# Altium schematic sheet Skywire BBBC.SchDoc
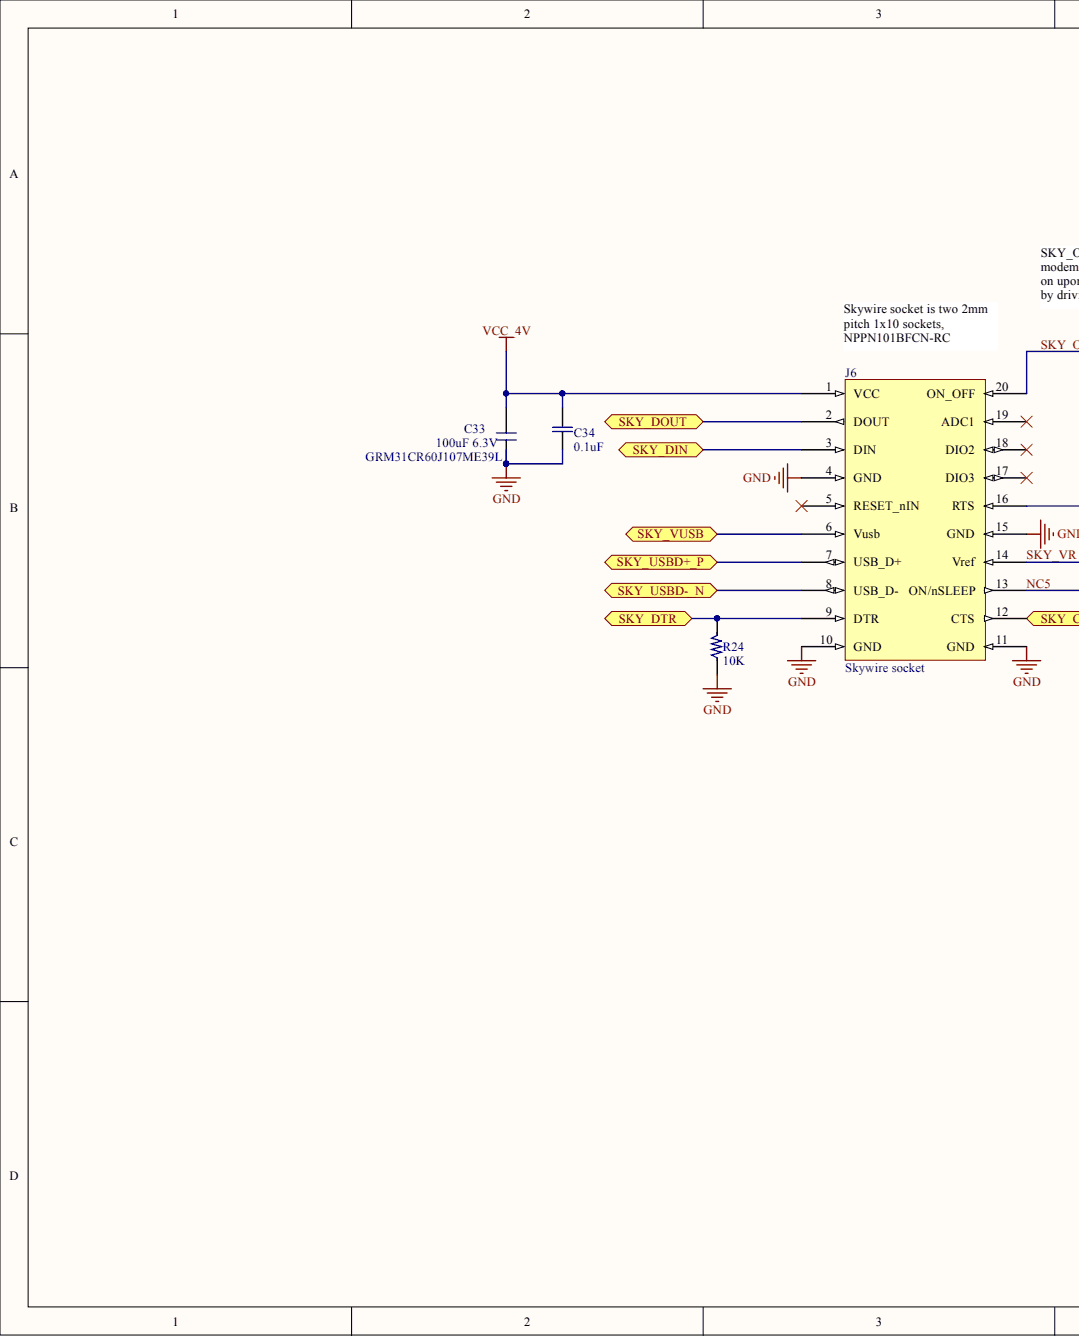
[diagram p0: Skywire BBBC.SchDoc, left]
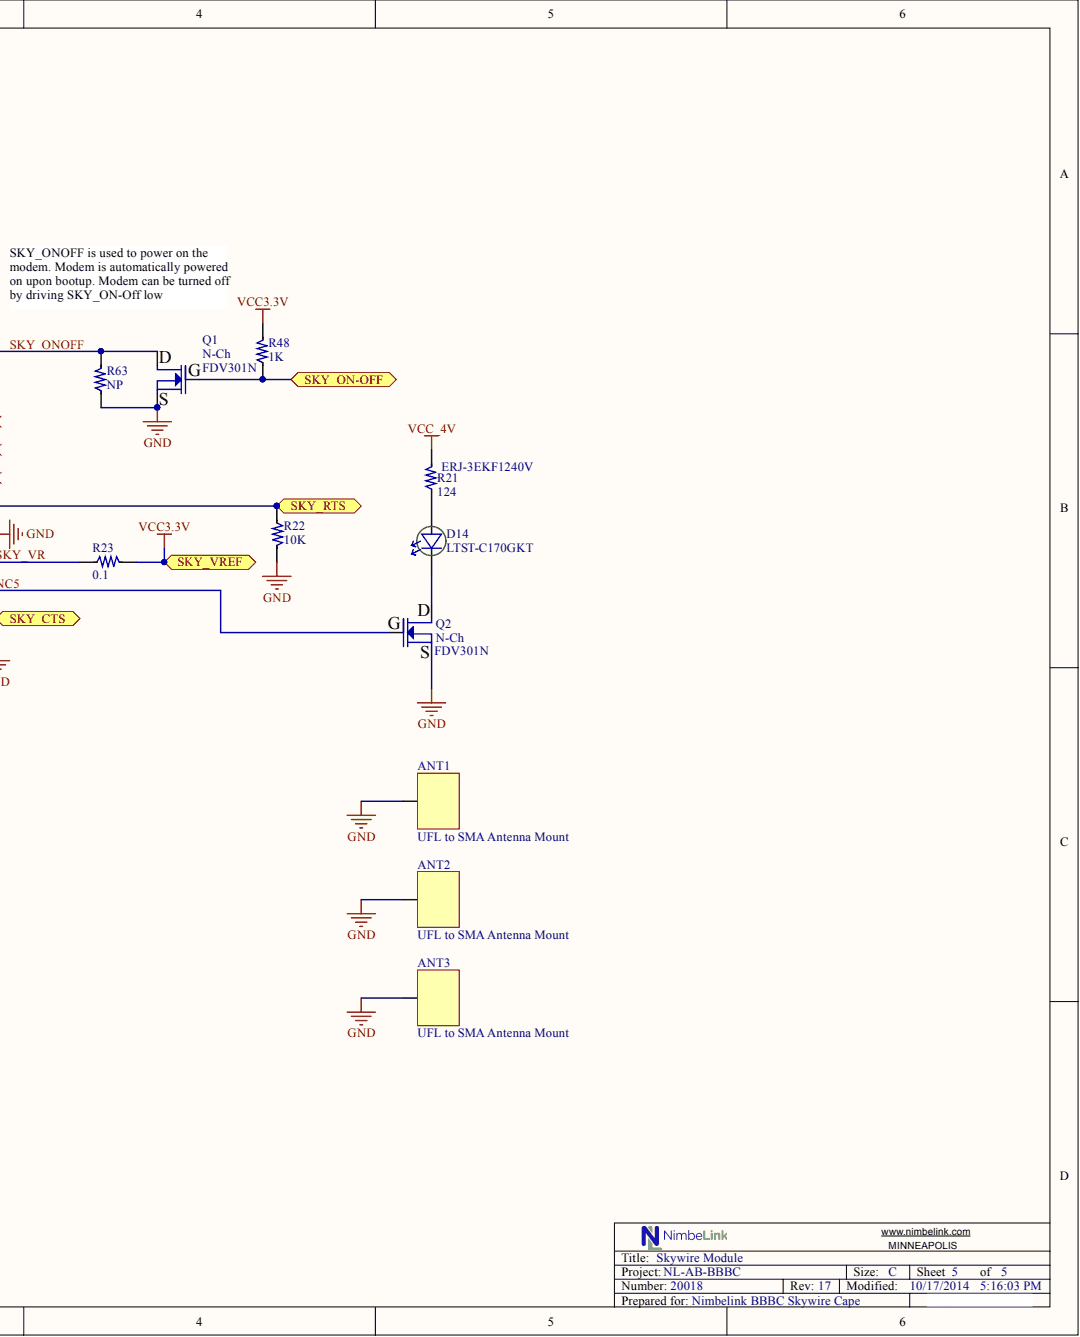
[diagram p1: Skywire BBBC.SchDoc, right]

## Parts
ANT1  UFL to SMA Antenna Mount  [UFL TO SMA]
ANT2  UFL to SMA Antenna Mount  [UFL TO SMA]
ANT3  UFL to SMA Antenna Mount  [UFL TO SMA]
C33  100uF 6.3V  Manufacturer Part Number 1: GRM31CR60J107ME39L  Voltage - Rated: 6.3V  [1206]
C34  0.1uF  [0603]
D14  Manufacturer Part Number 1: LTST-C170GKT  [D_LED_GREEN]  (hidden comment: D_LED_GREEN)
J6  Skywire socket  [SKYWIRE SOCKET]
Q1  N-Ch  Manufacturer Part Number 2: FDV301N  [SOT-23-3]
Q2  N-Ch  Manufacturer Part Number 2: FDV301N  [SOT-23-3]
R21  124  Manufacturer Part Number 1: ERJ-3EKF1240V  [0603]
R22  10K  [0603]
R23  0.1  [0805]
R24  10K  [0603]
R48  1K  [0603]
R63  NP  [0603]

## Ports
SKY_CTS, SKY_DIN, SKY_DOUT, SKY_DTR, SKY_ON-OFF, SKY_RTS, SKY_USBD+_P, SKY_USBD-_N, SKY_VREF, SKY_VUSB

## Nets
GND: ANT1.1 ANT2.1 ANT3.1 C33.2 C34.2 J6.4(GND) J6.10(GND) J6.11(GND) J6.15(GND) Q1.3 Q2.3 R22.2 R24.1 R63.1
VCC_4V: C33.1 C34.1 J6.1(VCC) R21.2
NC5: J6.13(ON/nSLEEP) Q2.1
SKY_CTS: J6.12(CTS) ; port SKY_CTS
SKY_DIN: J6.3(DIN) ; port SKY_DIN
SKY_DOUT: J6.2(DOUT) ; port SKY_DOUT
SKY_DTR: J6.9(DTR) R24.2 ; port SKY_DTR
SKY_ON-OFF: Q1.1 R48.1 ; port SKY_ON-OFF
SKY_ONOFF: J6.20(ON_OFF) Q1.2 R63.2
SKY_RTS: J6.16(RTS) R22.1 ; port SKY_RTS
SKY_USBD+_P: J6.7(USB_D+) ; port SKY_USBD+_P
SKY_USBD-_N: J6.8(USB_D-) ; port SKY_USBD-_N
SKY_VR: J6.14(Vref) R23.1
SKY_VUSB: J6.6(Vusb) ; port SKY_VUSB
VCC3.3V: R23.2 R48.2 ; port SKY_VREF
NetD14_1: D14.1 R21.1
NetD14_2: D14.2 Q2.2

Not connected: J6 5(RESET_nIN) 17(DIO3) 18(DIO2) 19(ADC1)

## Library data of the parts
ANT1-ANT3 = MTG UFL to SMA: Antenna Socket; Component Kind: Standard; Library Name: Nimbelink Altium Lib.SchLib
C33 = Cap 100uF 6.3V 20% X5R 1206 CER: Manufacturer 1: Murata Electronics North America; Capacitance: 100µF; Applications: General Purpose; Category: Capacitors; Component Kind: Standard; ComponentLink1Description: http://www.murata.com/products/catalog/pdf/c02e.pdf; ComponentLink1URL: http://www.murata.com/products/catalog/pdf/c02e.pdf; Description: Cap 100uF 6.3V 20% X5R 1206 CER; Features: -; Height - Seated (Max): -; Lead Spacing: -; Lead Style: -; Mounting Type: Surface Mount, MLCC; Operating Temperature: -55°C ~ 85°C; Package / Case: 1206 (3216 Metric); Packaging: Cut Tape (CT); Pricing: 1=0.81, 10=0.689, 50=0.507, 100=0.403, 250=0.338, 500=0.3055, 1000=0.2795 (USD); Ratings: -; RoHS: RoHS Compliant; Size / Dimension: 0.126" L x 0.063" W (3.20mm x 1.60mm); Stock: 5629; Supplier 1: Digi-Key; Supplier Part Number 1: 490-4539-1-ND; Temperature Coefficient: X5R; Thickness (Max): 0.071" (1.80mm); Tolerance: ±20%; Library Name: Nimbelink Altium Lib.SchLib
C34 = Cap 0.1uF 16V 10% X7R 0603 CER: Manufacturer 1: Samsung Electro-Mechanics America, Inc; Manufacturer Part Number 1: CL10B104KO8NNNC; Capacitance: 0.1µF; Applications: General Purpose; Category: Capacitors; Component Kind: Standard; ComponentLink1Description: http://www.samsungsem.com/servlet/FileDownload?type=spec&file=CL10B104KO8NNNC.pdf; ComponentLink1URL: http://www.samsungsem.com/servlet/FileDownload?type=spec&file=CL10B104KO8NNNC.pdf; Description: Cap 0.1uF 16V 10% X7R 0603 CER; Features: -; Height - Seated (Max): -; Lead Spacing: -; Lead Style: -; Mounting Type: Surface Mount, MLCC; Operating Temperature: -55°C ~ 125°C; Package / Case: 0603 (1608 Metric); Packaging: Cut Tape (CT); Pricing: 1=0.1, 10=0.019, 25=0.0132, 50=0.0102, 100=0.0086, 250=0.00704, 500=0.006, 1000=0.00468 (USD); Ratings: -; RoHS: RoHS Compliant; Size / Dimension: 0.063" L x 0.031" W (1.60mm x 0.80mm); Stock: 1252951; Supplier 1: Digi-Key; Supplier Part Number 1: 1276-1005-1-ND; Temperature Coefficient: X7R; Thickness (Max): 0.035" (0.90mm); Tolerance: ±10%; Voltage - Rated: 16V; Library Name: Nimbelink Altium Lib.SchLib
D14 = D_LED_GREEN: LED GREEN  SMD; Brand: Lite-On; Forward Current: 20 mA; Forward Voltage: 2.1 V; LED Size: 2 mm x 1.25 mm; Lens Color/Style: Water Clear; Luminous Intensity: 6 mcd; Viewing Angle: 130 deg; Wavelength/Color Temperature: 569 nm; Category: Standard LEDs - SMD; Component Kind: Standard; ComponentLink1Description: http://www.mouser.com/catalog/specsheets/Lite-On-LTST-C170GKT.pdf; ComponentLink1URL: http://www.mouser.com/catalog/specsheets/Lite-On-LTST-C170GKT.pdf; Illumination Color: Green; Manufacturer 1: Lite-On; Maximum Operating Temperature: + 85 C; Minimum Operating Temperature: - 55 C; Minimum Order Quantity: 1; Package / Case: 0805; Packaging: Reel; Pricing: 1=0.513, 10=0.371, 100=0.217, 250=0.154, 500=0.115, 1000=0.089, 3000=0.077, 6000=0.063, 12000=0.058 (AUD); Product Category: SMT LED; Reeling: True; RoHS: RoHS Compliant; RoHS - Mouser: Y; Standard Pack Qty: 3000; Stock: 13646; Supplier 1: Mouser; Supplier Part Number 1: 859-LTST-C170GKT; Library Name: Nimbelink Altium Lib.SchLib
J6 = Skywire socket: Manufacturer 1: Sullins Connector Solutions; Manufacturer Part Number 1: NPPN101BFCN-RC; Connector Type: Header; Number of Positions: 10; Number of Positions Loaded: All; Pitch: 0.079" (2.00mm); Category: Connectors, Interconnects; Color: Black; Component Kind: Standard; ComponentLink1Description: http://www.sullinscorp.com/drawings/75_1BFC_10483.pdf; ComponentLink1URL: http://www.sullinscorp.com/drawings/75_1BFC_10483.pdf; Contact Finish: Gold; Contact Finish Thickness: Flash; Contact Type: Female Socket; Fastening Type: -; Features: -; Mounting Type: Through Hole; Number of Rows: 1; Packaging: Bulk; Pricing: 1=1.23, 10=1.018, 25=0.9424, 50=0.8482, 100=0.754, 250=0.65976, 500=0.6032, 1000=0.54665, 2500=0.4901 (USD); Quantity: 2; RoHS: RoHS Compliant; Row Spacing: -; Stock: 17316; Supplier 1: Digi-Key; Supplier Part Number 1: S5751-10-ND; Termination: Solder; Library Name: Nimbelink Altium Lib.SchLib
Q1 Q2 = Q MOSFET N-CH 25V 220MA SOT-23: MOSFET N-CH 25V 220MA SOT-23; Brand: Fairchild Semiconductor; Channel Mode: Enhancement; Configuration: Single; Fall Time: 6 ns; Forward Transconductance - Min: 0.2 S; Pd - Power Dissipation: 0.35 W; Rds On - Drain-Source Resistance: 5 Ohms; Transistor Polarity: N-Channel; Typical Turn-Off Delay Time: 3.5 ns; Vgs - Gate-Source Breakdown Voltage: 8 V; Category: MOSFET; Component Kind: Standard; ComponentLink1Description: http://www.mouser.com/ds/2/149/FDV301N-52768.pdf; ComponentLink1URL: http://www.mouser.com/ds/2/149/FDV301N-52768.pdf; Id - Continuous Drain Current: 220 mA; Manufacturer 2: Fairchild Semiconductor; Maximum Operating Temperature: + 150 C; Minimum Operating Temperature: - 55 C; Minimum Order Quantity: 1; Mounting Style: SMD/SMT; Package / Case: SOT-23-3; Packaging: Reel; Pricing: 1=0.311, 10=0.209, 100=0.086, 1000=0.059, 3000=0.045, 9000=0.038, 24000=0.035, 45000=0.034, 99000=0.028 (AUD); Reeling: True; Rise Time: 6 ns; RoHS: RoHS Compliant; RoHS - Mouser: Y; Series: FDV301N; Standard Pack Qty: 3000; Stock: 403063; Supplier 1: Farnell; Supplier 2: Mouser; Supplier Part Number 1: 9845011; Supplier Part Number 2: 512-FDV301N; Vds - Drain-Source Breakdown Voltage: 25 V; Library Name: Nimbelink Altium Lib.SchLib
R21 = RES 124 1/10W 1% 0603: Brand: Panasonic; Case Height: 0.45 mm; Case Length: 1.6 mm; Case Width: 0.8 mm; Power Rating: 100 mW; Resistance: 124 Ohms; Voltage Rating: 75 V; Case Code - in: 0603; Case Code - mm: 1608; Category: Thick Film Resistors - SMD; Component Kind: Standard; ComponentLink1Description: http://industrial.panasonic.com/www-data/pdf/AOA0000/AOA0000CE2.pdf; ComponentLink1URL: http://industrial.panasonic.com/www-data/pdf/AOA0000/AOA0000CE2.pdf; Description: RES 124 1/10W 1% 0603; Manufacturer 1: Panasonic; Minimum Order Quantity: 1; Operating Temperature Range: - 55 C to + 155 C; Package / Case: 0603 (1608 metric); Packaging: Reel; Pricing: 1=0.135, 10=0.020, 100=0.011, 500=0.008, 1000=0.005, 5000=0.003 (AUD); Product: Thick Film Resistors SMD; Reeling: True; RoHS: RoHS Compliant By Exemption; RoHS - Mouser: E; Series: ERJ; Standard Pack Qty: 5000; Stock: 52556; Supplier 1: Mouser; Supplier Part Number 1: 667-ERJ-3EKF1240V; Tolerance: 1 %; Type: Precision Thick Film Resistors SMD; Library Name: Nimbelink Altium Lib.SchLib
R22 R24 = RES 10K 1/10W 1% 0603: Brand: Panasonic; Case Height: 0.45 mm; Case Length: 1.6 mm; Case Width: 0.8 mm; Power Rating: 100 mW; Resistance: 10 kOhms; Temperature Coefficient: 100 PPM / C; Voltage Rating: 75 V; Case Code - in: 0603; Case Code - mm: 1608; Category: Thick Film Resistors - SMD; Component Kind: Standard; ComponentLink1Description: http://industrial.panasonic.com/www-data/pdf/AOA0000/AOA0000CE2.pdf; ComponentLink1URL: http://industrial.panasonic.com/www-data/pdf/AOA0000/AOA0000CE2.pdf; Description: RES 10K 1/10W 1% 0603; Manufacturer 2: Panasonic; Manufacturer Part Number 2: ERJ-3EKF1002V; Minimum Order Quantity: 1; Operating Temperature Range: - 55 C to + 155 C; Package / Case: 0603 (1608 metric); Packaging: Reel; Pricing: 1=0.135, 10=0.019, 100=0.012, 500=0.009, 1000=0.005, 5000=0.004, 25000=0.003 (AUD); Product: Thick Film Resistors SMD; Reeling: True; RoHS: RoHS Compliant By Exemption; RoHS - Mouser: E; Series: ERJ; Standard Pack Qty: 5000; Stock: 1717350; Supplier 1: Digi-Key; Supplier 2: Mouser; Supplier Part Number 1: P10.0KHCT-ND; Supplier Part Number 2: 667-ERJ-3EKF1002V; Tolerance: 1 %; Type: Precision Thick Film Resistors SMD; Library Name: Nimbelink Altium Lib.SchLib
R23 = RES 0.1 1/3W 1% 0805: Brand: Susumu; Case Height: 0.4 mm; Case Length: 2 mm; Case Width: 1.25 mm; Power Rating: 250 mW (1/4 W); Resistance: 100 mOhms; Temperature Coefficient: 200 PPM / C; Case Code - in: 0805; Case Code - mm: 2012; Category: Current Sense Resistors - SMD; Component Kind: Standard; ComponentLink1Description: http://www.susumu.co.jp/product_pdf/all-list/e_15-16.pdf; ComponentLink1URL: http://www.susumu.co.jp/product_pdf/all-list/e_15-16.pdf; Description: RES 0.1 1/3W 1% 0805; Manufacturer 2: Susumu; Manufacturer Part Number 2: RL1220S-R10-F; Minimum Order Quantity: 1; Operating Temperature Range: - 55 C to + 125 C; Package / Case: 0805 (2012 metric); Packaging: Reel; PCB3D: Model Name; Pricing: 1=0.527, 10=0.235, 100=0.105, 1000=0.073, 2000=0.055, 5000=0.055, 10000=0.051, 25000=0.049, 100000=0.047 (AUD); Product: High Precision Current Sensing Metal Strip Resistors; Reeling: True; RoHS: RoHS Compliant; RoHS - Mouser: Y; Series: RL; Standard Pack Qty: 5000; Stock: 46395; Supplier 1: Digi-Key; Supplier 2: Mouser; Supplier Part Number 1: RL12S.10FCT-ND; Supplier Part Number 2: 754-RL1220S-R10-F; Termination Style: SMD/SMT; Tolerance: 1 %; Type: Low Resistance Value Chip Resistors; Library Name: Nimbelink Altium Lib.SchLib
R48 = RES 1K 1/10W 1% 0603: Manufacturer 1: Stackpole Electronics Inc; Manufacturer Part Number 1: RNCP0603FTD1K00; Power (Watts): 0.125W, 1/8W; Temperature Coefficient: ±100ppm/°C; Category: Resistors; Component Kind: Standard; ComponentLink1Description: http://www.seielect.com/catalog/SEI-RNCP.pdf; ComponentLink1URL: http://www.seielect.com/catalog/SEI-RNCP.pdf; Composition: Thin Film; Description: RES 1K 1/10W 1% 0603; Features: Anti-Sulfur; Height: 0.020" (0.50mm); Number of Terminations: 2; Package / Case: 0603 (1608 Metric); Packaging: Cut Tape (CT); Pricing: 1=0.05, 10=0.038, 50=0.0208, 100=0.0158, 250=0.01172, 500=0.00864, 1000=0.00576, 2500=0.00486 (USD); Resistance (Ohms): 1k; RoHS: RoHS Compliant; Size / Dimension: 0.059" L x 0.031" W (1.50mm x 0.80mm); Stock: 1735; Supplier 1: Digi-Key; Supplier Device Package: 0603; Supplier Part Number 1: RNCP0603FTD1K00CT-ND; Tolerance: ±1%; Library Name: Nimbelink Altium Lib.SchLib
R63 = RES NP: Component Kind: Standard; Description: NP; Library Name: Nimbelink Altium Lib.SchLib
